# Altium schematic sheet Singlepath.SchDoc
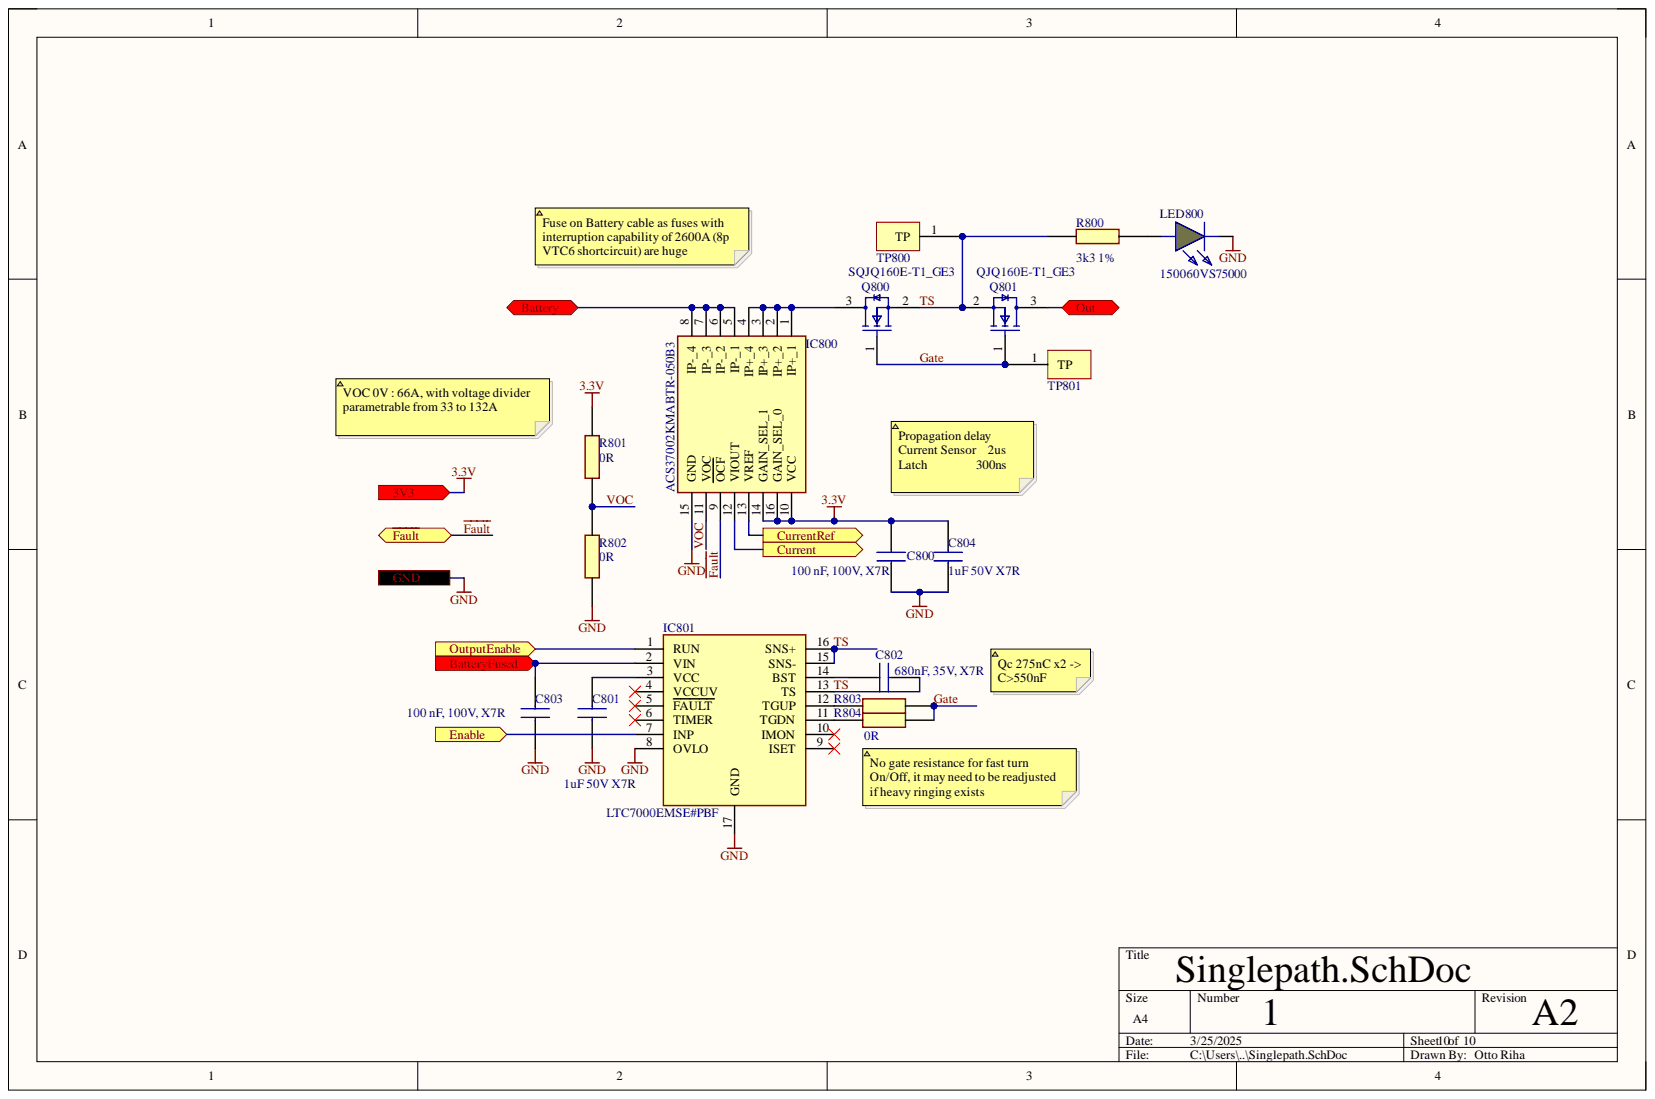
[diagram p0: Singlepath.SchDoc]

## Parts
C800  100 nF, 100V, X7R  [MAJx16]
C801  1uF 50V X7R  value 1uF  [CAPC1608X90N]
C802  680nF, 35V, X7R  [CAPC1608X90N]
C803  100 nF, 100V, X7R  [MAJx16]
C804  1uF 50V X7R  value 1uF  [CAPC1608X90N]
IC800  ACS37002KMABTR-050B3  [SOIC127P1030X265-16N]
IC801  LTC7000EMSE#PBF  [SOP50P490X110-17N]
LED800  150060VS75000  [LEDC1608X80N]
Q800  SQJQ160E-T1_GE3  [SQJQ160ET1_GE3]
Q801  QJQ160E-T1_GE3  [SQJQ160ET1_GE3]
R800  3k3 1%  value 3k3  [RESC1608X55N]
R801  value 0R  [RESC1608X50N]  (hidden comment: 0Ohm)
R802  value 0R  [RESC1608X50N]  (hidden comment: 0Ohm)
R803  0Ohm  [RESC1608X50N]  (value not drawn)
R804  0Ohm  [RESC1608X50N]  (value not drawn)
TP800  Test Pad 1mm  [Test Pad 1mm-Footprint-1]  (value not drawn)
TP801  Test Pad 1mm  [Test Pad 1mm-Footprint-1]  (value not drawn)

## Ports
3V3, Battery, BatteryFused, Current, CurrentRef, Enable, GND, Out, OutputEnable, ~{Fault}

## Nets
3.3V: C800.2 C804.2 IC800.10(VCC) IC800.14(GAIN_SEL_1) IC800.16(GAIN_SEL_0) R801.2 ; port 3V3
Battery: IC800.5(IP-_1) IC800.6(IP-_2) IC800.7(IP-_3) IC800.8(IP-_4) ; port Battery
BatteryFused: C803.2 IC801.2(VIN) ; port BatteryFused
Current: IC800.12(VIOUT) ; port Current
CurrentRef: IC800.13(VREF) ; port CurrentRef
Enable: IC801.7(INP) ; port Enable
~{Fault}: IC800.9(OCF) ; port ~{Fault}
Gate: Q800.1 Q801.1 R803.2 R804.2 TP801.1
GND: C800.1 C801.1 C803.1 C804.1 IC800.15(GND) IC801.8(OVLO) IC801.17(GND) LED800.1 R802.1 ; port GND
Out: Q801.3 ; port Out
OutputEnable: IC801.1(RUN) ; port OutputEnable
TS: C802.2 IC801.13(TS) IC801.15(SNS-) IC801.16(SNS+) Q800.2 Q801.2 R800.1 TP800.1
VOC: IC800.11(VOC) R801.1 R802.2
NetC801_2: C801.2 IC801.3(VCC)
NetC802_1: C802.1 IC801.14(BST)
NetIC800_1: IC800.1(IP+_1) IC800.2(IP+_2) IC800.3(IP+_3) IC800.4(IP+_4) Q800.3
NetIC801_4: IC801.4(VCCUV)
NetIC801_5: IC801.5(FAULT)
NetIC801_6: IC801.6(TIMER)
NetIC801_9: IC801.9(ISET)
NetIC801_10: IC801.10(IMON)
NetIC801_11: IC801.11(TGDN) R804.1
NetIC801_12: IC801.12(TGUP) R803.1
NetLED800_2: LED800.2 R800.2

## Library data of the parts
C800 C803 = MAJCH168BB7104KTEA01: Capacitor 100nF, 100V, X7R; Manufacturer_Name: TAIYO YUDEN; Arrow Part Number: MAJCH168BB7104KTEA01; Arrow Price/Stock: https://www.arrow.com/en/products/majch168bb7104ktea01/taiyo-yuden?utm_currency=USD&region=nac; Component Kind: Standard; Datasheet Link: https://ds.yuden.co.jp/TYCOMPAS/or/detail?pn=MAJCH168BB7104KTEA01; Height: 0.8mm; Library Name: SamacSys.SchLib; Manufacturer_Part_Number: MAJCH168BB7104KTEA01; Mouser Part Number: 963-MAJCH168BB7104KT; Mouser Price/Stock: https://www.mouser.co.uk/ProductDetail/TAIYO-YUDEN/MAJCH168BB7104KTEA01?qs=ZcfC38r4PovaTBrG0IdezQ%3D%3D; Supplier 1: Mouser; Supplier Part Number 1: 963-MAJCH168BB7104KT
C801 C804 = 06035C105MAT2A: Capacitor 1uF 50V X7R; Manufacturer_Name: Kyocera AVX; Component Kind: Standard; Datasheet Link: https://componentsearchengine.com/Datasheets/1/06031A100FAT2A.pdf; Height: 0.9mm; Library Name: SamacSys.SchLib; Manufacturer_Part_Number: 06035C105MAT2A; Mouser Part Number: 581-06035C105MAT2A; Mouser Price/Stock: https://www.mouser.co.uk/ProductDetail/KYOCERA-AVX/06035C105MAT2A?qs=%252BdQmOuGyFcHMsevPtc6OZQ%3D%3D
C802 = CGA3E1X7R1V684K080AC: Multilayer Ceramic Chip Capacitors; Manufacturer_Name: TDK; Component Kind: Standard; Datasheet Link: http://docs-europe.electrocomponents.com/webdocs/14e9/0900766b814e9125.pdf; Height: 0.9mm; Library Name: SamacSys.SchLib; Manufacturer_Part_Number: CGA3E1X7R1V684K080AC; Supplier 1: Mouser; Supplier Part Number 1: 810-CGA3E1X7R1V684KC
IC800 = ACS37002KMABTR-050B3: Integrated Circuit; Manufacturer_Name: Allegro Microsystems; Component Kind: Standard; Datasheet Link: https://www.allegromicro.com/-/media/files/datasheets/acs37002-datasheet.ashx?sc_lang=en&hash=FFD236A6D18C7717C5A7906548FB48D2; Height: 2.65mm; Library Name: SamacSys.SchLib; Manufacturer_Part_Number: ACS37002KMABTR-050B3; Mouser Part Number: 250-37002KMABTR050B3; Mouser Price/Stock: https://www.mouser.co.uk/ProductDetail/Allegro-MicroSystems/ACS37002KMABTR-050B3?qs=pUKx8fyJudCSE%2FoW6XxDYQ%3D%3D
IC801 = LTC7000EMSE#PBF: Integrated Circuit; Manufacturer_Name: Analog Devices; Arrow Part Number: LTC7000EMSE#PBF; Arrow Price/Stock: https://www.arrow.com/en/products/ltc7000emsepbf/analog-devices?region=nac; Component Kind: Standard; Datasheet Link: https://www.analog.com/media/en/technical-documentation/data-sheets/ltc7000-7000-1.pdf; Height: 1.1mm; Library Name: SamacSys.SchLib; Manufacturer_Part_Number: LTC7000EMSE#PBF; Mouser Part Number: 584-LTC7000EMSEPBF; Mouser Price/Stock: https://www.mouser.co.uk/ProductDetail/Analog-Devices/LTC7000EMSEPBF?qs=BZBei1rCqCABaEvAxqf5Sw%3D%3D
LED800 = 150060VS75000: LED; Manufacturer_Name: Wurth Elektronik; Component Kind: Standard; Datasheet Link: https://componentsearchengine.com/Datasheets/2/150060VS75000.pdf; Height: 0.8mm; Library Name: SamacSys.SchLib; Manufacturer_Part_Number: 150060VS75000; Mouser Part Number: 710-150060VS75000; Mouser Price/Stock: https://www.mouser.co.uk/ProductDetail/Wurth-Elektronik/150060VS75000?qs=LlUlMxKIyB1Q1Bi5mQ%2FKLw%3D%3D
Q800 Q801 = SQJQ160E-T1_GE3: MOSFET (N-Channel); Manufacturer_Name: Vishay; Component Kind: Standard; Datasheet Link: https://www.vishay.com/docs/63059/sqjq160e.pdf; Height: 1.65mm; Library Name: SamacSys.SchLib; Manufacturer_Part_Number: SQJQ160E-T1_GE3; Mouser Part Number: 78-SQJQ160E-T1_GE3; Mouser Price/Stock: https://www.mouser.co.uk/ProductDetail/Vishay-Semiconductors/SQJQ160E-T1_GE3?qs=Wj%2FVkw3K%252BMDBWZlqh0rWDw%3D%3D
R800 = CRGH0603F3K3: Resistor 3k3 1%; Manufacturer_Name: TE Connectivity; Component Kind: Standard; Datasheet Link: http://docs-europe.electrocomponents.com/webdocs/12d7/0900766b812d7379.pdf; Height: 0.55mm; Library Name: SamacSys.SchLib; Manufacturer_Part_Number: CRGH0603F3K3; Supplier 1: Avnet; Supplier Part Number 1: 46AK9312
R801 R802 R804 = CRCW06030000Z0EC: Resistor; Manufacturer_Name: Vishay; Arrow Part Number: CRCW06030000Z0EC; Arrow Price/Stock: https://www.arrow.com/en/products/crcw06030000z0ec/vishay?utm_currency=USD&region=nac; Component Kind: Standard; Datasheet Link: http://www.vishay.com/docs/20035/dcrcwe3.pdf; Height: 0.5mm; Library Name: SamacSys.SchLib; Manufacturer_Part_Number: CRCW06030000Z0EC; Mouser Part Number: 71-CRCW06030000Z0EC; Mouser Price/Stock: https://www.mouser.co.uk/ProductDetail/Vishay-Dale/CRCW06030000Z0EC?qs=5Vo7YKalRVV5nT3RQodYAA%3D%3D
R803 = CRCW06030000Z0EC: Resistor; Manufacturer_Name: Vishay; Value: 0R; Arrow Part Number: CRCW06030000Z0EC; Arrow Price/Stock: https://www.arrow.com/en/products/crcw06030000z0ec/vishay?utm_currency=USD&region=nac; Component Kind: Standard; Datasheet Link: http://www.vishay.com/docs/20035/dcrcwe3.pdf; Height: 0.5mm; Library Name: SamacSys.SchLib; Manufacturer_Part_Number: CRCW06030000Z0EC; Mouser Part Number: 71-CRCW06030000Z0EC; Mouser Price/Stock: https://www.mouser.co.uk/ProductDetail/Vishay-Dale/CRCW06030000Z0EC?qs=5Vo7YKalRVV5nT3RQodYAA%3D%3D
TP800 TP801 = CMP-002-00031-3: 1mm radius circuilar test pad; Component Kind: Standard; Library Name: EPFL Racing Team
